# Altium schematic sheet Schematics.SchDoc
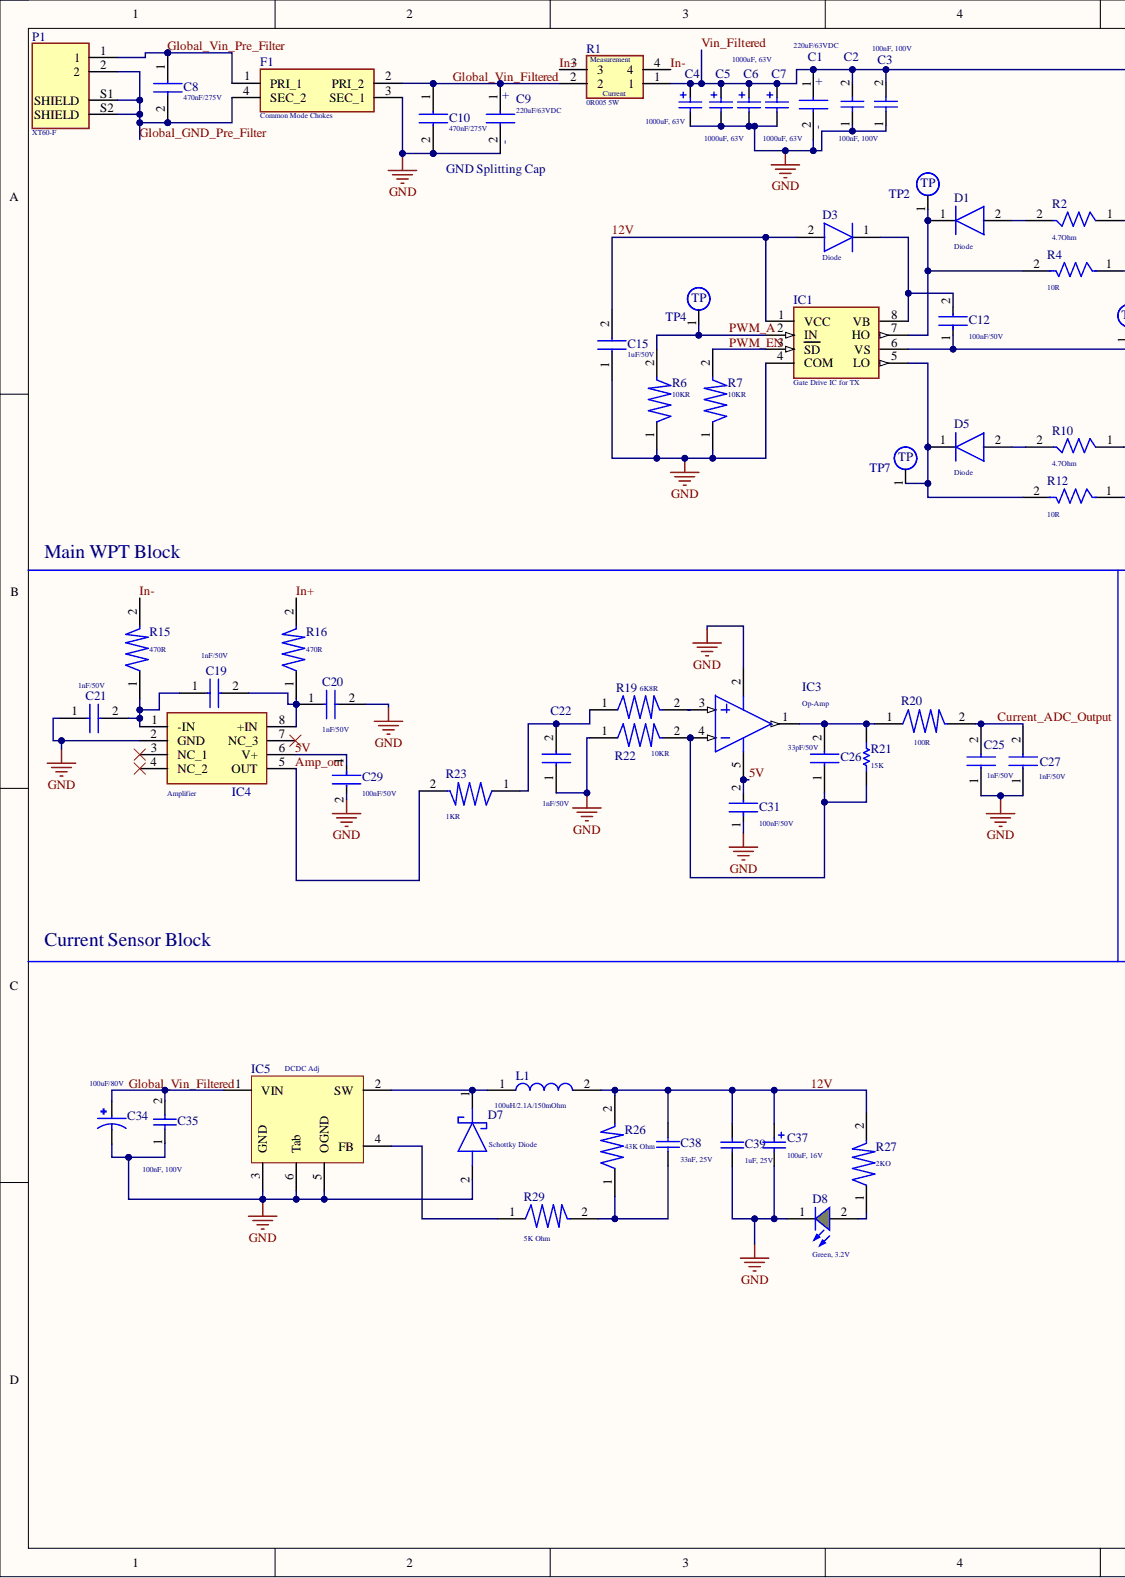
[diagram p0: Schematics.SchDoc, left]
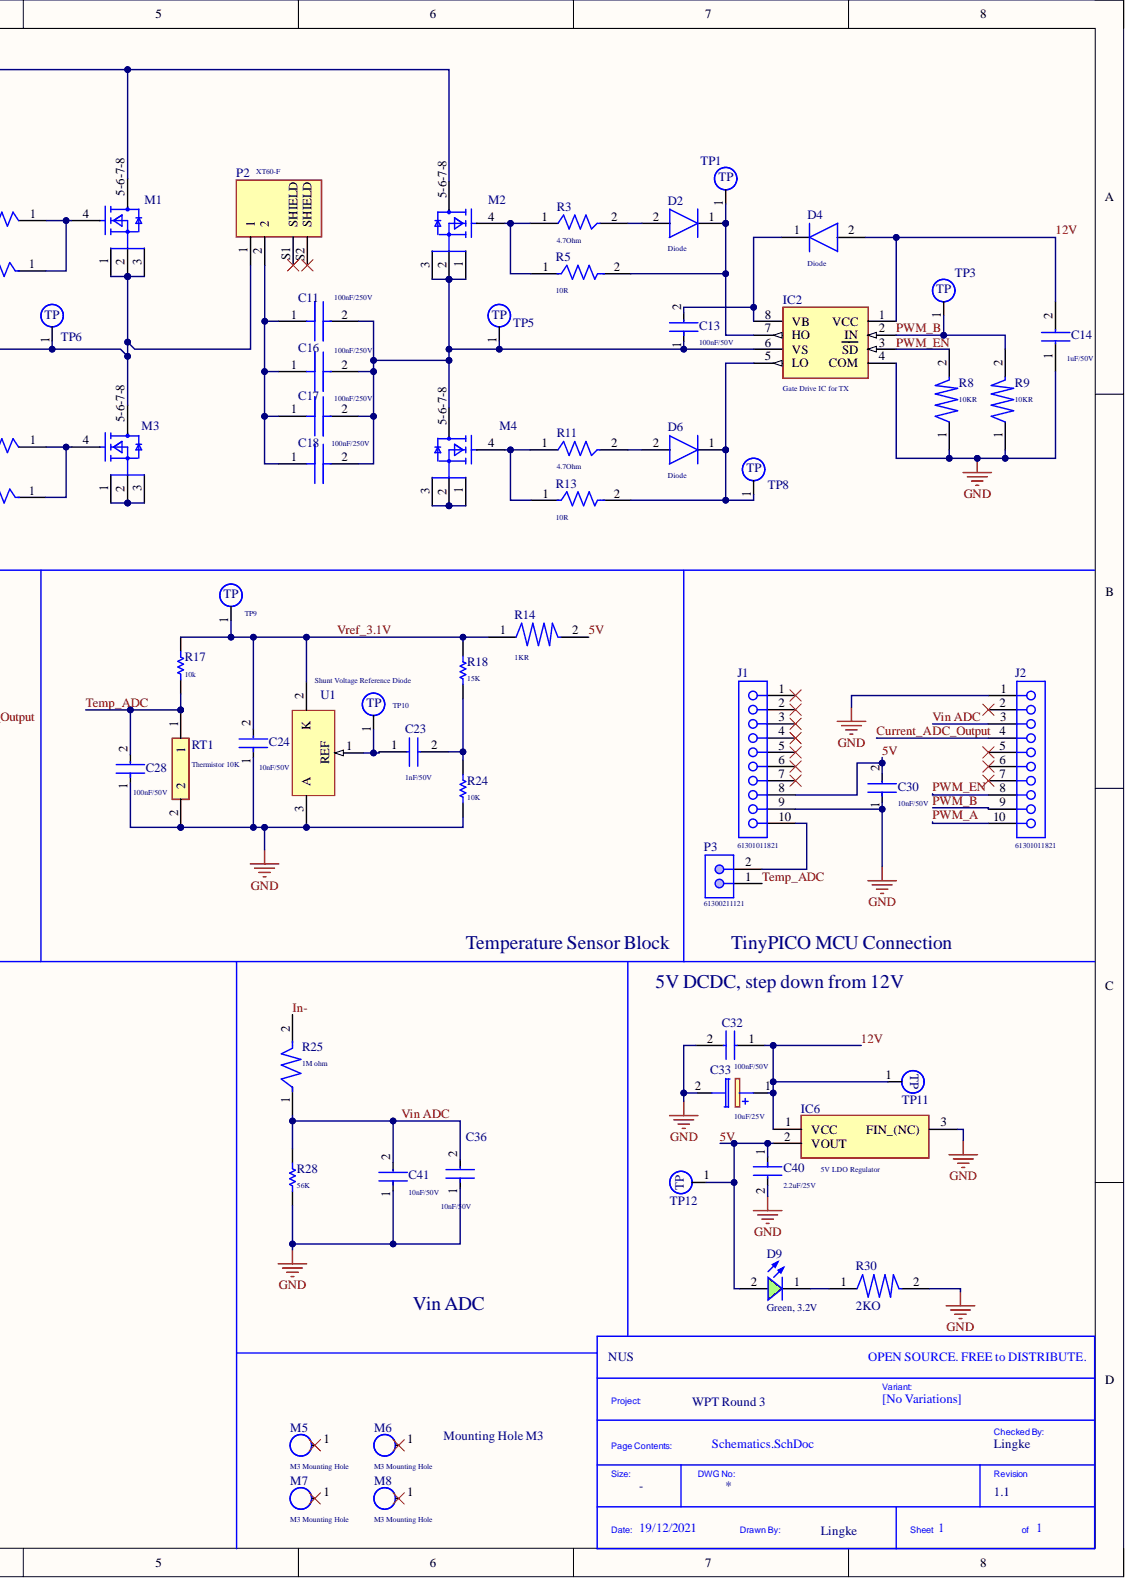
[diagram p1: Schematics.SchDoc, right]

## Parts
*  Checked By: Lingke  Company: NUS  Project Name: WPT Round 3  Revision: 1.1  (hidden comment: Title_Block)  (no BOM)
C1  220uF/63VDC  [CAPPRD500W62D1000H2650]
C2  100nF, 100V  [WCAP-CSGP_0805_H=1.25mm]
C3  100nF, 100V  [WCAP-CSGP_0805_H=1.25mm]
C4  1000uF, 63V  [WCAP-ATG8_D16H25]
C5  1000uF, 63V  [WCAP-ATG8_D16H25]
C6  1000uF, 63V  [WCAP-ATG8_D16H25]
C7  1000uF, 63V  [WCAP-ATG8_D16H25]
C8  470nF/275V  [890324025039CS]
C9  220uF/63VDC  [CAPPRD500W62D1000H2650]
C10  470nF/275V  [890324025039CS]
C11  100nF/250V  [CAPC4532X220N]
C12  100nF/50V  [0805 Capacitor]
C13  100nF/50V  [0805 Capacitor]
C14  1uF/50V  [CAPC2012X135N]
C15  1uF/50V  [CAPC2012X135N]
C16  100nF/250V  [CAPC4532X220N]
C17  100nF/250V  [CAPC4532X220N]
C18  100nF/250V  [CAPC4532X220N]
C19  1nF/50V  [CAPC2012X90N]
C20  1nF/50V  [CAPC2012X90N]
C21  1nF/50V  [CAPC2012X90N]
C22  1nF/50V  [CAPC2012X90N]
C23  1nF/50V  [CAPC2012X90N]
C24  10nF/50V  [0805 Capacitor]
C25  1nF/50V  [CAPC2012X90N]
C26  33pF/50V  [CAPC2012X90N]
C27  1nF/50V  [CAPC2012X90N]
C28  100nF/50V  [0805 Capacitor]
C29  100nF/50V  [0805 Capacitor]
C30  10nF/50V  [0805 Capacitor]
C31  100nF/50V  [0805 Capacitor]
C32  100nF/50V  [CAPC2012X90N]
C33  10uF/25V  [CAPAE530X550N]
C34  100uF/80V  [CAP_EEEFK1K101AV]
C35  100nF, 100V  [WCAP-CSGP_0805_H=1.25mm]
C36  10nF/50V  [0805 Capacitor]
C37  100uF, 16V  [WCAP-ASLI_D6.3H5.5]
C38  33nF, 25V  [WCAP-CSGP_0805_H=0.8mm_Reflow]
C39  1uF, 25V  [WCAP-CSGP_0805_H=1.25mm]
C40  2.2uF/25V  [0805 Capacitor]
C41  10nF/50V  [0805 Capacitor]
D1  Diode  [SOD3716X135N]
D2  Diode  [SOD3716X135N]
D3  Diode  [SOD3716X135N]
D4  Diode  [SOD3716X135N]
D5  Diode  [SOD3716X135N]
D6  Diode  [SOD3716X135N]
D7  Schottky Diode  [DIONM8059X265N]
D8  Green, 3.2V  Forward Voltage typ.: 3.2V  [WL-SMCW_0805]
D9  Green, 3.2V  Forward Voltage typ.: 3.2V  [WL-SMCW_0805]
F1  Common Mode Chokes  [PA5141205NLT]
IC1  Gate Drive IC for TX  [SOIC127P600X175-8N]
IC2  Gate Drive IC for TX  [SOIC127P600X175-8N]
IC3  Op-Amp  [ADI-RJ-5_N]
IC4  Amplifier  [SOIC127P600X175-8N]
IC5  DCDC Adj  [TO-263-5]
IC6  5V LDO Regulator  [ROHM_TO-252-3]
J1  61301011821  [61301011821]
J2  61301011821  [61301011821]
L1  100uH/2.1A/150mOhm  [INDPM125125X800N]
M1  Mosfet  [FP-PG-TDSON-8-MFG]  (value not drawn)
M2  Mosfet  [FP-PG-TDSON-8-MFG]  (value not drawn)
M3  Mosfet  [FP-PG-TDSON-8-MFG]  (value not drawn)
M4  Mosfet  [FP-PG-TDSON-8-MFG]  (value not drawn)
M5  M3 Mounting Hole  [M3 Hole]
M6  M3 Mounting Hole  [M3 Hole]
M7  M3 Mounting Hole  [M3 Hole]
M8  M3 Mounting Hole  [M3 Hole]
P1  XT60-F  [AMASS_XT60PW-F]
P2  XT60-F  [AMASS_XT60PW-F]
P3  61300211121  [61300211121]
R1  0R005 5W  [FC4L110R005FER]
R2  4.7Ohm  [FP-CRCW1206-e3-IPC_A]
R3  4.7Ohm  [FP-CRCW1206-e3-IPC_A]
R4  10R  [FP-CRCW1206-e3-IPC_A]
R5  10R  [FP-CRCW1206-e3-IPC_A]
R6  10KR  [FP-CRCW0805-e3-MFG]
R7  10KR  [FP-CRCW0805-e3-MFG]
R8  10KR  [FP-CRCW0805-e3-MFG]
R9  10KR  [FP-CRCW0805-e3-MFG]
R10  4.7Ohm  [FP-CRCW1206-e3-IPC_A]
R11  4.7Ohm  [FP-CRCW1206-e3-IPC_A]
R12  10R  [FP-CRCW1206-e3-IPC_A]
R13  10R  [FP-CRCW1206-e3-IPC_A]
R14  1KR  [FP-CRCW0805-e3-MFG]
R15  470R  [FP-CRCW0805-e3-MFG]
R16  470R  [FP-CRCW0805-e3-MFG]
R17  10k  [0805 Resistor]
R18  15K  [0805 Resistor]
R19  6K8R  [FP-CRCW0805-e3-MFG]
R20  100R  [FP-CRCW0805-e3-MFG]
R21  15K  [0805 Resistor]
R22  10KR  [FP-CRCW0805-e3-MFG]
R23  1KR  [FP-CRCW0805-e3-MFG]
R24  10K  [0805 Resistor]
R25  1M ohm  [FP-CRCW0805-e3-MFG]
R26  43K Ohm  [FP-CRCW0805-e3-MFG]
R27  2KO  [FP-CRCW0805-e3-MFG]
R28  56K  [0805 Resistor]
R29  5K Ohm  [FP-CRCW0805-e3-MFG]
R30  2KO  [FP-CRCW0805-e3-MFG]
RT1  Thermistor 10K  [THRMC2012X95N]
TP1  TP  [Test Point]  (no BOM)
TP2  TP  [Test Point]  (no BOM)
TP3  TP  [Test Point]  (no BOM)
TP4  TP  [Test Point]  (no BOM)
TP5  TP  [Test Point]  (no BOM)
TP6  TP  [Test Point]  (no BOM)
TP7  TP  [Test Point]  (no BOM)
TP8  TP  [Test Point]  (no BOM)
TP9  TP  [Test Point]  (no BOM)
TP10  TP  [Test Point]  (no BOM)
TP11  TP  [Test Point]  (no BOM)
TP12  TP  [Test Point]  (no BOM)
U1  Shunt Voltage Reference Diode  [SOT23-3_N]

## Nets
GND: C1.2 C2.1 C3.1 C4.2 C5.2 C6.2 C7.2 C9.2 C10.2 C14.1 C15.1 C20.2 C21.1 C22.1 C24.1 C25.1 C27.1 C28.1 C29.2 C30.1 C31.1 C32.2 C33.2 C34.2 C35.1 C36.1 C37.2 C39.1 C40.2 C41.1 D7.2 D8.1 F1.3(SEC_1) IC1.4(COM) IC2.4(COM) IC3.2 IC4.2(GND) IC5.3(GND) IC5.5(OGND) IC5.6(Tab) IC6.3 J1.9 J2.1 R6.1 R7.1 R8.1 R9.1 R22.1 R24.1 R28.1 R30.2 RT1.2 U1.3
5V: C29.1 C30.2 C31.2 C40.1 D9.2 IC3.5 IC4.6(V+) IC6.2 J1.8 R14.2 TP12.1
12V: C14.2 C15.2 C32.1 C33.1 C37.1 C38.2 C39.2 D3.2 D4.2 IC1.1(VCC) IC2.1(VCC) IC6.1 L1.2 R26.2 R27.2 TP11.1
Amp_out: IC4.5(OUT) R23.2
Current_ADC_Output: C25.2 C27.2 J2.4 R20.2
Global_GND_Pre_Filter: C8.2 F1.4(SEC_2) P1.2 P1.S1(SHIELD) P1.S2(SHIELD)
Global_Vin_Filtered: C9.1 C10.1 C34.1 C35.2 F1.2(PRI_2) IC5.1(VIN) R1.2
Global_Vin_Pre_Filter: C8.1 F1.1(PRI_1) P1.1
In+: R1.3 R16.2
In-: R1.4 R15.2 R25.2
PWM_A: IC1.2(IN) J2.10 R6.2 TP4.1
PWM_B: IC2.2(IN) J2.9 R9.2 TP3.1
PWM_EN: IC1.3(SD) IC2.3(SD) J2.8 R7.2 R8.2
Temp_ADC: C28.2 P3.1 R17.1 RT1.1
Vin ADC: C36.2 C41.2 J2.3 R25.1 R28.2
Vin_Filtered: C1.1 C2.2 C3.2 C4.1 C5.1 C6.1 C7.1 M1.5-6-7-8 M2.5-6-7-8 R1.1
Vref_3.1V: C24.2 R14.1 R17.2 R18.2 TP9.1 U1.2
NetC11_1: C11.1 C16.1 C17.1 C18.1 P2.2
NetC11_2: C11.2 C13.1 C16.2 C17.2 C18.2 IC2.6(VS) M2.1 M2.2 M2.3 M4.5-6-7-8 TP5.1
NetC12_1: C12.1 IC1.6(VS) M1.1 M1.2 M1.3 M3.5-6-7-8 P2.1 TP6.1
NetC12_2: C12.2 D3.1 IC1.8(VB)
NetC13_2: C13.2 D4.1 IC2.8(VB)
NetC19_1: C19.1 C21.2 IC4.1(-IN) R15.1
NetC19_2: C19.2 C20.1 IC4.8(+IN) R16.1
NetC22_2: C22.2 R19.1 R23.1
NetC23_1: C23.1 TP10.1 U1.1
NetC23_2: C23.2 R18.1 R24.2
NetC26_1: C26.1 IC3.4 R21.1 R22.2
NetC26_2: C26.2 IC3.1 R20.1 R21.2
NetC38_1: C38.1 R26.1 R29.2
NetD1_1: D1.1 IC1.7(HO) R4.2 TP2.1
NetD1_2: D1.2 R2.2
NetD2_1: D2.1 IC2.7(HO) R5.2 TP1.1
NetD2_2: D2.2 R3.2
NetD5_1: D5.1 IC1.5(LO) R12.2 TP7.1
NetD5_2: D5.2 R10.2
NetD6_1: D6.1 IC2.5(LO) R13.2 TP8.1
NetD6_2: D6.2 R11.2
NetD7_1: D7.1 IC5.2(SW) L1.1
NetD8_2: D8.2 R27.1
NetD9_1: D9.1 R30.1
NetIC3_3: IC3.3 R19.2
NetIC5_4: IC5.4(FB) R29.1
NetJ1_10: J1.10 P3.2
NetM1_4: M1.4 R2.1 R4.1
NetM2_4: M2.4 R3.1 R5.1
NetM3_1: M3.1 M3.2 M3.3
NetM3_4: M3.4 R10.1 R12.1
NetM4_1: M4.1 M4.2 M4.3
NetM4_4: M4.4 R11.1 R13.1

Not connected: IC4 3(NC_1) 4(NC_2) 7(NC_3); J1 1 2 3 4 5 6 7; J2 2 5 6 7; M5 1; M6 1; M7 1; M8 1; P2 S1(SHIELD) S2(SHIELD)

## Library data of the parts
* = Title_Block: Standard Title Block.; Library Name: Symbol Library.SchLib; Size: -; Drawn By: Lingke
C1 C9 = 860040775010: Polarised Cap, 220uF/63VDC; Manufacturer_Name: Wurth Elektronik; Component Kind: Standard; Datasheet Link: https://www.mouser.de/datasheet/2/445/860040775010-1725627.pdf; Height: 26.5mm; Library Name: Symbol Library.SchLib; Manufacturer_Part_Number: 860040775010
C2 C3 C35 = 885012207128: WCAP-CSGP Multilayer Ceramic Chip Capacitor, General Purpose, size 0805, X7R, 100nF, 100V; Manufacturer: Wurth Elektronik; Capacitance Tolerance: +/-10%; Dissipation Factor: #NAME?; Isolation Resistance: >=1GOhm; Rated Voltage: 100VDC; Technical Reference: X7R0805104K100DFCT10000; Capacitance: 100nF; Ceramic Type: X7R; Component Kind: Standard; ComponentLink1Description: Manufacturer; ComponentLink1URL: http://www.we-online.de; ComponentLink2Description: Datasheet; ComponentLink2URL: https://www.we-online.com/catalog/datasheet/885012207128.pdf; Height: 1.25mm; Library Name: Symbol Library.SchLib; Manufacturer Part Number: 885012207128; Mounting Technology: SMT; Operating Temperature: -55 to +125degC; Packaging: Tape and Reel; Published Date: May 2020; Size: 0805
C4-C7 = 860010780024: WCAP-ATG8 Aluminum Electrolytic Capacitor, Radial, THT, D16xH25, 1000uF, 63V; Manufacturer: Wurth Elektronik; Capacitance Tolerance: +/-20%; Dissipation Factor: 9%; Endurance: 2000h; Leakage Current: 630uA; Rated Voltage: 63VDC; Ripple Current: 1540mA; Technical Reference: ATBK250102M063DSPAEB000; Capacitance: 1000uF; Component Kind: Standard; ComponentLink1Description: Manufacturer; ComponentLink1URL: http://www.we-online.de; ComponentLink2Description: Datasheet; ComponentLink2URL: https://www.we-online.com/catalog/datasheet/860010780024.pdf; Diameter: 16mm; Height: 25mm; Library Name: Altium_WCAP-ATG8 (rev20b).IntLib; Manufacturer Part Number: 860010780024; Mounting Technology: THT; Operating Temperature: -40 to +85degC; Packaging: Ammo Pack; Published Date: October 2020
C8 C10 = 890324025039CS: Safety Capacitor, 470nF/275V; Manufacturer_Name: Wurth Elektronik; Component Kind: Standard; Height: 14.5mm; Library Name: Symbol Library.SchLib; Manufacturer_Part_Number: 890324025039CS
C11 C16-C18 = C1812C104JAGACAUTO: Manufacturer_Name: Kemet; Component Kind: Standard; Datasheet Link: https://api.kemet.com/component-edge/download/datasheet/C1812C104JAGACAUTO.pdf; Description: 100nF/250V; Height: 2.2mm; Library Name: Symbol Library.SchLib; Manufacturer_Part_Number: C1812C104JAGACAUTO; Supplier 1: Mouser; Supplier Part Number 1: 80-C1812C104JAGAUTO
C12 C13 C28 C29 C31 = 885012207098: Component Kind: Standard; Description: 100nF/50V; Library Name: Symbol Library.SchLib; Supplier 1: Mouser; Supplier Part Number 1: 710-885012207098
C14 C15 = 885012207103: Capacitor 1uF/50V; Manufacturer_Name: Wurth Elektronik; Component Kind: Standard; Datasheet Link: https://katalog.we-online.com/pbs/datasheet/885012207103.pdf; Height: 1.35mm; Library Name: Symbol Library.SchLib; Manufacturer_Part_Number: 885012207103; Supplier 1: Mouser; Supplier Part Number 1: 710-885012207103
C19-C23 C25 C27 = 885012207086: Manufacturer_Name: Wurth Elektronik; Component Kind: Standard; Datasheet Link: https://katalog.we-online.com/pbs/datasheet/885012207086.pdf; Description: 1nF/50V; Height: 0.9mm; Library Name: Symbol Library.SchLib; Manufacturer_Part_Number: 885012207086; Supplier 1: Mouser; Supplier Part Number 1: 710-885012207086
C24 C30 C36 C41 = 885012207092: Component Kind: Standard; Description: 10nF/50V; Library Name: Symbol Library.SchLib; Supplier 1: Mouser; Supplier Part Number 1: 710-885012207092
C26 = C0805C330J5GACTU: Capacitor 33pF/50V; Manufacturer_Name: Kemet; Component Kind: Standard; Library Name: Symbol Library.SchLib; Manufacturer_Part_Number: C0805C330J5GACTU; Supplier 1: Mouser; Supplier Part Number 1: 80-C0805C330J5G
C32 = 885012207098: Manufacturer_Name: Wurth Elektronik; Component Kind: Standard; Description: 100nF/50V; Height: 0.9mm; Library Name: Symbol Library.SchLib; Supplier 1: Mouser; Supplier Part Number 1: 710-885012207098
C33 = 865060440001: Capacitor Polarised 10uF/25V; Manufacturer_Name: Wurth Elektronik; Component Kind: Standard; Datasheet Link: http://katalog.we-online.com/pbs/datasheet/865060440001.pdf; Height: 5.5mm; Library Name: Symbol Library.SchLib; Manufacturer_Part_Number: 865060440001
C34 = EEE-FK1K101AV: 100ÂµF 80V Aluminum Electrolytic Capacitors Radial, Can - SMD 320mOhm @ 100kHz 5000 Hrs @ 105Â°C; MF: Panasonic; MP: EEEFK1K101AV; Availability: In Stock; Check_prices: https://www.snapeda.com/parts/EEEFK1K101AV/Panasonic/view-part/5786341/?ref=eda; Component Kind: Standard; Library Name: Symbol Library.SchLib; Package: RADIAL-2 Panasonic; Price: None; Purchase-URL: https://pricing.snapeda.com/search/part/EEEFK1K101AV/?ref=eda; SnapEDA_Link: https://www.snapeda.com/parts/EEEFK1K101AV/Panasonic/view-part/5786341/?ref=snap
C37 = 865080343009: WCAP-ASLI Aluminum Electrolytic Capacitor, V-Chip, SMT, D6.3xH5.5mm, 100uF, 16V; Manufacturer: Wurth Elektronik; Capacitance Tolerance: +/-20%; Dissipation Factor: 16%; Endurance: 2000h; Leakage Current: 16uA; Rated Voltage: 16VDC; Ripple Current: 255mA; Technical Reference: ASED055101M016DVCTBB000; Capacitance: 100uF; Component Kind: Standard; ComponentLink1Description: Manufacturer; ComponentLink1URL: http://www.we-online.de; ComponentLink2Description: Datasheet; ComponentLink2URL: https://www.we-online.com/catalog/datasheet/865080343009.pdf; Diameter: 6.3mm; Height: 5.5mm; Library Name: Altium_WCAP-ASLI (rev20b).IntLib; Manufacturer Part Number: 865080343009; Mounting Technology: SMT; Operating Temperature: -55 to +105degC; Packaging: Tape and Reel; Published Date: October 2020
C38 = 885012207069: WCAP-CSGP Multilayer Ceramic Chip Capacitor, General Purpose, size 0805, X7R, 33nF, 25V; Manufacturer: Wurth Elektronik; Capacitance Tolerance: +/-10%; Dissipation Factor max.: 3.5%; Isolation Resistance  min.: 10GOhm; Rated Voltage: 25VDC; Technical Reference: X7R0805333K025DFCT10000; Capacitance: 33nF; Ceramic Type: X7R; Component Kind: Standard; ComponentLink1Description: Manufacturer; ComponentLink1URL: http://www.we-online.de; ComponentLink2Description: Datasheet; ComponentLink2URL: https://www.we-online.com/catalog/datasheet/885012207069.pdf; Height: 0.8mm; Library Name: Altium_WCAP-CSGP (rev21d).IntLib; Manufacturer Part Number: 885012207069; Mounting Technology: SMT; Operating Temperature: -55 to +125degC; Packaging: Tape and Reel; Published Date: April 2021; Q-Factor min.: -; Size: 0805
C39 = 885012207078: WCAP-CSGP Multilayer Ceramic Chip Capacitor, General Purpose, size 0805, X7R, 1uF, 25V; Manufacturer: Wurth Elektronik; Capacitance Tolerance: +/-10%; Dissipation Factor max.: 5%; Isolation Resistance  min.: 0.5GOhm; Rated Voltage: 25VDC; Technical Reference: X7R0805105K025DFCT10000; Capacitance: 1uF; Ceramic Type: X7R; Component Kind: Standard; ComponentLink1Description: Manufacturer; ComponentLink1URL: http://www.we-online.de; ComponentLink2Description: Datasheet; ComponentLink2URL: https://www.we-online.com/catalog/datasheet/885012207078.pdf; Height: 1.25mm; Library Name: Altium_WCAP-CSGP (rev21d).IntLib; Manufacturer Part Number: 885012207078; Mounting Technology: SMT; Operating Temperature: -55 to +125degC; Packaging: Tape and Reel; Published Date: April 2021; Q-Factor min.: -; Size: 0805
C40 = 885012207079: Capacitance: 2.2; Dielectric: X5R; Voltage Rating (DC): 25V; Case Code (Imperial): 0805; Case Code (Metric): 2012; Case/Package: 0805; Component Kind: Standard; Description: 2.2uF/25V; Library Name: Symbol Library.SchLib; Max Operating Temperature: 125°C; Package Quantity: 2000; Supplier 1: Mouser; Supplier Part Number 1: 710-885012207079
D1-D6 = 1N4148W-E3-08: Manufacturer_Name: Vishay; Component Kind: Standard; Datasheet Link: https://componentsearchengine.com//1N4148W-E3-08.pdf; Description: Diode; Height: 1.35mm; Library Name: Symbol Library.SchLib; Manufacturer_Part_Number: 1N4148W-E3-08; Mouser2 Part Number: 78-1N4148W-E3-08; Mouser2 Price/Stock: https://www.mouser.com/Search/Refine.aspx?Keyword=78-1N4148W-E3-08; Supplier 1: Digi-Key; Supplier Part Number 1: 1N4148W-E3-08CT-ND
D7 = S310: Manufacturer_Name: ON Semiconductor; Arrow Part Number: S310; Arrow Price/Stock: https://www.arrow.com/en/products/s310/on-semiconductor?region=nac; Component Kind: Standard; Datasheet Link: https://componentsearchengine.com/Datasheets/2/S310.pdf; Description: Schottky Diode; Height: 2.65mm; Library Name: Symbol Library.SchLib; Manufacturer_Part_Number: S310; Mouser Part Number: 512-S310; Mouser Price/Stock: https://www.mouser.co.uk/ProductDetail/ON-Semiconductor-Fairchild/S310?qs=AZz8fAaf6P%2FJSlbiibJLxA%3D%3D
D8 D9 = 150080GS75000: WL-SMCW SMT Mono-color Chip LED Waterclear, size 0805, Green, 3.2V, 140deg; Chip Technology: InGaN; Dominant Wavelength typ.: 525nm; Luminous Intensity typ.: 450mcd; Peak Wavelength typ.: 515nm; Component Kind: Standard; ComponentLink1Description: Manufacturer; ComponentLink1URL: http://www.we-online.de; ComponentLink2Description: Datasheet; ComponentLink2URL: https://www.we-online.com/catalog/datasheet/150080GS75000.pdf; Emitting Color: Green; Library Name: Altium_WL-SMCW (rev20a).IntLib; Mounting Technology: SMT; Operating Temperature: -40 to +85degC; Packaging: Tape and Reel; Part Number: 150080GS75000; Published Date: December 2019; Size: 0805; Viewing Angle: 140deg; Publisher: Wurth Elektronik
F1 = PA5141.205NLT: Filter; Manufacturer_Name: Pulse; Component Kind: Standard; Datasheet Link: https://products.pulseelex.com/files/product_files/P860.pdf; Height: 22.7mm; Library Name: Symbol Library.SchLib; Manufacturer_Part_Number: PA5141.205NLT; Mouser Part Number: 673-PA5141.205NLT; Mouser Price/Stock: https://www.mouser.co.uk/ProductDetail/Pulse-Electronics/PA5141205NLT?qs=l7cgNqFNU1hhs8iQ7nyooA%3D%3D
IC1 IC2 = IRS2008SPBF: Gate Drive IC; Manufacturer_Name: Infineon; Component Kind: Standard; Height: 1.75mm; Library Name: Symbol Library.SchLib; Manufacturer_Part_Number: IRS2008SPBF; Supplier 1: Mouser; Supplier Part Number 1: 726-IRS2008SPBF
IC3 = AD8031ARTZ-REEL7: Single Channel RRIO Operational Amplifier, 80 MHz BW, 35 V/us SR, Industrial, 5-pin SOT23 (RJ-5), Reel; Generic Part Number: AD8031; Manufacturer: Analog Devices; Amplifiers Per Package: 1; Input Bias Current: 450nA; Input Offset Voltage: 1mV; Iq/Amp: 900uA; Slew Rate: 35V/us; Small Signal Bandwidth: 80MHz; V Span Max: 12V; V Span Min: 2.7V; Code_JEDEC: MO-178-AA; Component Kind: Standard; ComponentLink1Description: Manufacturer URL; ComponentLink1URL: http://www.analog.com/en/index.html; ComponentLink2Description: Datasheet; ComponentLink2URL: http://www.analog.com/static/imported-files/data_sheets/AD8031_8032.pdf; ComponentLink3Description: Package Specification; ComponentLink3URL: http://www.analog.com/static/imported-files/packages/PKG_PDF/SOT-23(RJ)/RJ_5.pdf; ComponentLink4Description: Reference Circuit; ComponentLink4URL: http://www.analog.com/en/all-operational-amplifiers-op-amps/operational-amplifiers-op-amps/ad8031/products/product.html#product-recommendations; DatasheetVersion: Rev. D; Library Name: Symbol Library.SchLib; Mounting Technology: Surface Mount; PackageDescription: 5-Pin Small Outline Transistor Package, Body 2.9 x 1.6 mm, Pitch 0.95 mm; PackageReference: RJ-5; PackageVersion: 121608-A; Packing: Reel; PartNumber: AD8031ARTZ-REEL7; RevisionNote: Reference Circuit URL fixed; Supplier 1: Mouser; Supplier Part Number 1: 584-AD8031ARTZ-R7
IC4 = AD8215YRZ: Integrated Circuit Amplifier; Manufacturer_Name: Analog Devices; Component Kind: Standard; Datasheet Link: http://www.analog.com/static/imported-files/data_sheets/AD8215.pdf; Height: 1.75mm; Library Name: Symbol Library.SchLib; Manufacturer_Part_Number: AD8215YRZ; Supplier 1: Mouser; Supplier Part Number 1: 584-AD8215YRZ
IC5 = XL7035E1: Component Kind: Standard; Library Name: Symbol Library.SchLib
IC6 = BD450M5FP-CE2: Manufacturer_Name: ROHM Semiconductor; Component Kind: Standard; Datasheet Link: https://componentsearchengine.com//BD450M5FP-CE2.pdf; Description: 5V LDO Regulator; Library Name: Symbol Library.SchLib; Manufacturer_Part_Number: BD450M5FP-CE2; Mouser2 Part Number: 755-BD450M5FP-CE2; Mouser2 Price/Stock: https://www.mouser.com/Search/Refine.aspx?Keyword=755-BD450M5FP-CE2
J1 J2 = 61301011821: WR-PHD Socket Header, THT, pitch 2.54mm, Single Row, Vertical, 10p; Manufacturer: Wurth Elektronik; Contact Resistance: 20mOhm; Pin Number: 10; Rated Current: 3A; Withstanding Voltage: 500VAC; Working Voltage: 250VAC; Component Kind: Standard; ComponentLink1Description: Manufacturer; ComponentLink1URL: http://www.we-online.de; ComponentLink2Description: Datasheet; ComponentLink2URL: https://www.we-online.com/catalog/datasheet/61301011821.pdf; Gender: Socket Header; Library Name: Altium_WR-PHD (rev20a).IntLib; Manufacturer Part Number: 61301011821; Mounting Technology: THT; Operating Temperature: -40 to +125degC; Orientation: Vertical; Packaging: Tray; Pitch: 2.54mm; Published Date: May 2020; Row Number: Single
L1 = SRR1280-101M: Inductor; Manufacturer_Name: Bourns; Arrow Part Number: SRR1280-101M; Arrow Price/Stock: https://www.arrow.com/en/products/srr1280-101m/bourns; Component Kind: Standard; Datasheet Link: https://componentsearchengine.com/Datasheets/2/SRR1280-101M.pdf; Height: 8mm; Library Name: Symbol Library.SchLib; Manufacturer_Part_Number: SRR1280-101M; Mouser Part Number: 652-SRR1280-101M; Mouser Price/Stock: https://www.mouser.co.uk/ProductDetail/Bourns/SRR1280-101M?qs=brMhP%2FMjUfd8D85Ep5aW7g%3D%3D
M1-M4 = BSC040N10NS5ATMA1: MOSFET N-CH 100V 100A 8TDSON  Mosfet Switcher; Manufacturer: Infineon; Depletion Mode: False; Drain To Source Resistance: 3.4mΩ; Gate Charge At Vgs: 58nC @ 10V; Gate To Source Voltage: 20V; Input Capacitace At Vds: 4100pF @ 50V; Max Forward Diode Voltage: 1.1V; Max Junction Temp: +150°C; Number Of Channels: 1; Power Dissipation: 139W; Pulse Drain Current: 400A; Reverse Recovery Charge: 90nC; Reverse Recovery Time: 54ns; Rthja Max: 50K/W; Threshold Vgs Max: 3.8V; Threshold Vgs Min: 2.2V; Transconductance: 120S; Turn Off Delay Time: 32ns; Turn On Delay Time: 13ns; Typ Forward Diode Voltage: 0.9V; Automotive: No; Category: Trans; Component Kind: Standard; ComponentLink2Description: Datasheet; ComponentLink2URL: https://www.infineon.com/dgdl/Infineon-BSC040N10NS5-DS-v02_02-EN.pdf?fileId=5546d4624a0bf290014a0fbcee2e6b38; Continuous Drain Current: 100A; Device Class L1: Discrete Semiconductors; Device Class L2: Transistors; Device Class L3: MOSFETs; Digikey Description: MOSFET N-CH 100V 100A 8TDSON; Drain To Source Breakdown Voltage: 100V; Drain To Source Voltage: 100V; Footprint Url: https://www.infineon.com/dgdl/Infineon-PG-TDSON-8-7-FP-v01_00-EN.pdf?fileId=5546d46259d9a4bf015a1b6adadd1f0a; Height: 1.1mm; Lead Free: Yes; Library Name: Symbol Library.SchLib; Manufacturer Part Number: BSC040N10NS5ATMA1; Mouser Description: MOSFET N-Ch 100V 100A TDSON-8; Number Of N Channels: 1; Octopart: https://octopart.com/bsc040n10ns5atma1-infineon-52825711; Package: PG-TDSON-8; RoHS: TRUE; Supplier 1: Mouser; Supplier Part Number 1: 726-BSC040N10NS5ATMA; Temperature: -55°C to +150°C; Temperature Range High: +150°C; Temperature Range Low: -55°C
M5-M8 = M3_Mounting_hole: Component Kind: Standard; Library Name: Symbol Library.SchLib
P1 P2 = XT60PW-F: Socket DC Supply Connector Female; MF: AMASS; Availability: Unavailable; BuyPart: https://www.snapeda.com/parts/XT60PW-F/AMASS/view-part/2890674/?ref=eda; Component Kind: Standard; Library Name: Symbol Library.SchLib; MP: XT60PW-F; Package: Package; Price: None; SnapEDA_Link: https://www.snapeda.com/parts/XT60PW-F/AMASS/view-part/2890674/?ref=snap
P3 = 61300211121: WR-PHD Pin Header, THT, pitch 2.54mm, Single Row, Vertical, 2p; Manufacturer: Wurth Elektronik; Contact Resistance: 20mOhm; Pin Number: 2; Rated Current: 3A; Withstanding Voltage: 500VAC; Working Voltage: 250VAC; Component Kind: Standard; ComponentLink1Description: Manufacturer; ComponentLink1URL: http://www.we-online.de; ComponentLink2Description: Datasheet; ComponentLink2URL: https://www.we-online.com/catalog/datasheet/61300211121.pdf; Gender: Pin Header; Library Name: Altium_WR-PHD (rev20a).IntLib; Manufacturer Part Number: 61300211121; Mounting Technology: THT; Operating Temperature: -40 to +105degC; Orientation: Vertical; Packaging: Bag; Pitch: 2.54mm; Published Date: May 2020; Row Number: Single
R1 = FC4L110R005FER: Resistor 0R005 5W; Manufacturer_Name: Ohmite; Component Kind: Standard; Height: 0.7mm; Library Name: Symbol Library.SchLib; Manufacturer_Part_Number: FC4L110R005FER; Supplier 1: Mouser; Supplier Part Number 1: 588-FC4L110R005FER
R2 R3 R10 R11 = CRCW12064R70FKEA: RES Thick Film, 4.7Ω, 1%, 0.25W, 100ppm/°C, 1206  MOSFET Gate Fast-off Resistor 4.7Ohm; Max Power Dissipation: 250mW; Resistance: 4.7R; Voltage Rating: 200V; Case Code (Imperial): 1206; Case Code (Metric): 3216; Case/Package: 1206; Component Kind: Standard; Composition: Thick Film; Contact Plating: Tin; Depth: 1.6mm; Features: Automotive AEC-Q200; Height: 0.024inch; Length: 3.2mm; Library Name: Symbol Library.SchLib; Max Operating Temperature: 155°C; Military Standard: Not; Min Operating Temperature: -55°C; Mount: Surface Mount; Number of Pins: 2; Number of Terminations: 2; Package Quantity: 10; Packaging: Tape and Reel; Power Rating: 250mW; Radiation Hardening: No; Supplier 1: Mouser; Supplier Part Number 1: 71-CRCW12064R70FKEA; Temperature Coefficient: 100ppm/°C; Tolerance: 1%; Weight: 0.000353oz; Width: 0.063inch
R4 R5 R12 R13 = CRCW120610R0FKEA: RES Thick Film, 10Ω, 1%, 0.25W, 100ppm/°C, 1206  MOSFET Gate Resistor 10Ohm; Max Power Dissipation: 250mW; Voltage Rating: 200V; Case Code (Imperial): 1206; Case Code (Metric): 3216; Case/Package: 1206; Component Kind: Standard; Composition: Thick Film; Contact Plating: Tin; Depth: 1.6mm; Features: Automotive AEC-Q200; Height: 0.55mm; Lead Free: Lead Free; Length: 3.2mm; Library Name: Symbol Library.SchLib; Max Operating Temperature: 155°C; Military Standard: Not; Min Operating Temperature: -55°C; Number of Pins: 2; Number of Terminations: 2; Package Quantity: 10; Packaging: Tape and Reel; Power Rating: 250mW; Radiation Hardening: No; Resistance: 10R; Supplier 1: Digi-Key; Supplier Part Number 1: 541-10.0FDKR-ND; Temperature Coefficient: 100ppm/°C; Termination: SMD/SMT; Tolerance: 1%; Voltage Rating (DC): 200V; Weight: 0.000353oz; Width: 0.063inch
R6-R9 R22 = CRCW080510K0FKEA: Resistor 10K Ohm; Component Kind: Standard; Library Name: Symbol Library.SchLib; Number of Terminations: 2; Supplier 1: Mouser; Supplier Part Number 1: 71-CRCW0805-10K-E3
R14 R23 = CRCW08051K00FKEA: Resistor 1k Ohm; Component Kind: Standard; Library Name: Symbol Library.SchLib; Number of Terminations: 2; Supplier 1: Mouser; Supplier Part Number 1: 71-CRCW0805-1.0K-E3
R15 R16 = CRCW0805470RFKEB: Resistor 470 Ohm; Component Kind: Standard; Library Name: Symbol Library.SchLib; Number of Terminations: 2; Supplier 1: Mouser; Supplier Part Number 1: 71-CRCW0805470RFKEB
R17 = ERA-6ARB103V: High Presition and High reliability 10K Ohm Resistor; Component Kind: Standard; Library Name: Symbol Library.SchLib; Supplier 1: Mouser; Supplier Part Number 1: 667-ERA-6ARB103V
R18 = ERA-6ARW153V: High Presicion 15K Ohm; Component Kind: Standard; Library Name: Symbol Library.SchLib; Supplier 1: Mouser; Supplier Part Number 1: 667-ERA-6ARW153V
R19 = CRCW08056K80FKEA: Resistor 6.8K Ohm; Component Kind: Standard; Library Name: Symbol Library.SchLib; Number of Terminations: 2; Supplier 1: Mouser; Supplier Part Number 1: 71-CRCW0805-6.8K-E3
R20 = CRCW0805100RFKEB: Resistor 100 Ohm; Component Kind: Standard; Library Name: Symbol Library.SchLib; Number of Terminations: 2; Supplier 1: Mouser; Supplier Part Number 1: 71-CRCW0805100RFKEB
R21 = ERA-6AED153V: 15K Ohm Resistor; Component Kind: Standard; Library Name: Symbol Library.SchLib; Supplier 1: Mouser; Supplier Part Number 1: 667-ERA-6AED153V
R24 = CRCW080510K0FKEB: High Presicion 10K Ohm; Component Kind: Standard; Library Name: Symbol Library.SchLib; Supplier 1: Newark; Supplier Part Number 1: 26R4046
R25 = CRCW08051M00FKEA: RES Thick Film, 1MΩ, 1%, 0.125W, 100ppm/°C, 0805  1M Ohm Resistor; Power Rating: 125mW; Resistance: 1MR; Voltage Rating: 150V; Case Code (Imperial): 0805; Case Code (Metric): 2012; Case/Package: 0805; Component Kind: Standard; Composition: Thick Film; Contact Plating: Tin; Depth: 1.25mm; Height: 0.45mm; Lead Free: Lead Free; Length: 2mm; Library Name: Symbol Library.SchLib; Max Operating Temperature: 155°C; Military Standard: Not; Min Operating Temperature: -55°C; Mount: Surface Mount; Number of Pins: 2; Package Quantity: 5000; Packaging: Tape and Reel; Radiation Hardening: No; Supplier 1: Mouser; Supplier Part Number 1: 71-CRCW08051M00FKEAH; Temperature Coefficient: 100ppm/°C; Termination: SMD/SMT; Tolerance: 1%; Voltage Rating (DC): 150V; Weight: 0.000194oz; Width: 1.25mm
R26 = RC0805FR-0743KL: Resistor 43K Ohm; Component Kind: Standard; Library Name: Symbol Library.SchLib; Number of Terminations: 2; Supplier 1: Mouser; Supplier Part Number 1: 71-CRCW08051K30FKEB
R27 R30 = CRCW08052K00FKEB: RES Thick Film, 2kΩ, 1%, 0.125W, 100ppm/°C, 0805; Max Power Dissipation: 125mW; Resistance: 2kR; Voltage Rating: 150V; Case Code (Imperial): 0805; Case Code (Metric): 2012; Case/Package: 0805; Component Kind: Standard; Composition: Thick Film; Contact Plating: Tin; Features: Automotive AEC-Q200; Height: 0.02inch; Lead Free: Lead Free; Length: 0.079inch; Library Name: Symbol Library.SchLib; Max Operating Temperature: 155°C; Min Operating Temperature: -55°C; Number of Pins: 2; Number of Terminations: 2; Package Quantity: 10000; Packaging: Tape and Reel; Power Rating: 125mW; Radiation Hardening: No; Supplier 1: Mouser; Supplier Part Number 1: 71-CRCW08052K00FKEB; Temperature Coefficient: 100ppm/°C; Termination: SMD/SMT; Tolerance: 1%; Voltage Rating (DC): 150V; Weight: 0.000194oz; Width: 0.049inch
R28 = ERJ-6ENF5622V: 56K Ohm Resistor; Component Kind: Standard; Library Name: Symbol Library.SchLib; Supplier 1: Mouser; Supplier Part Number 1: 667-ERJ-6ENF5622V
R29 = CRCW08055K00FKTA: Resistor 5K Ohm; Component Kind: Standard; Library Name: Symbol Library.SchLib; Number of Terminations: 2; Supplier 1: Mouser; Supplier Part Number 1: 71-CRCW08051K30FKEB
RT1 = NTCS0805E3103HMT: Thermistor 10Kohm B Parameter: 3950k; Manufacturer_Name: Vishay; Component Kind: Standard; Datasheet Link: http://www.vishay.com/docs/29044/ntcs0805e3t.pdf; Height: 0.95mm; Library Name: Symbol Library.SchLib; Manufacturer_Part_Number: NTCS0805E3103HMT; Supplier 1: Mouser; Supplier Part Number 1: 594-NTCS0805E3103HMT
TP1-TP12 = Test Point: Test Point 1mm by 1mm; Library Name: Symbol Library.SchLib
U1 = TS432AILT: Shunt voltage reference, adjustable, 1.24 to 10V, 0.5% precision, 3-Pin SOT23-3L, Tape and Reel; Component Kind: Standard; ComponentLink1Description: Manufacturer URL; ComponentLink1URL: http://www.st.com; ComponentLink2Description: Datasheet; ComponentLink2URL: http://www.st.com/internet/com/TECHNICAL_RESOURCES/TECHNICAL_LITERATURE/DATASHEET/CD00003202.pdf; DatasheetVersion: Apr-2008; Library Name: Symbol Library.SchLib; PackageDescription: 3-Pin SOT-23 Package 0.95 mm Pitch, 2.4 mm Lead Span; PackageReference: SOT23-3; PackageVersion: 2011; Supplier 1: Digi-Key; Supplier Part Number 1: 497-TS432AILTCT-ND
